# Altium schematic sheet LQV103SYSV2.SchDoc
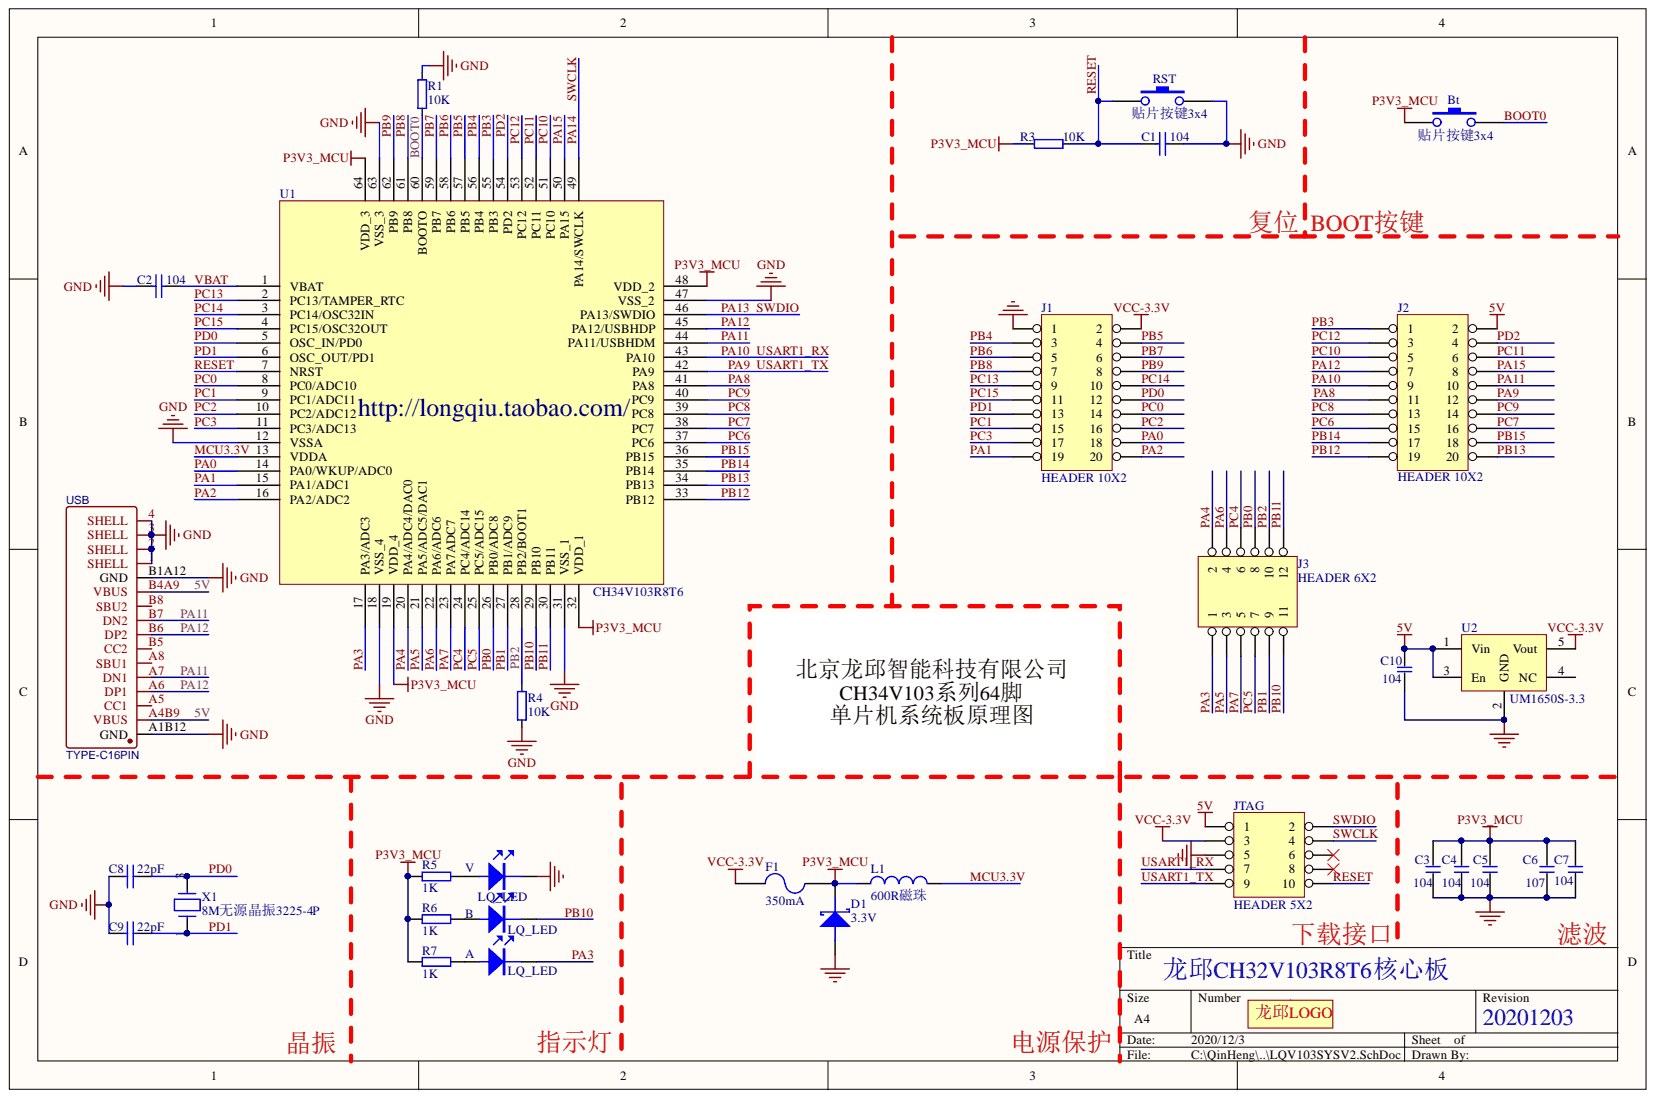
[diagram p0: LQV103SYSV2.SchDoc]

## Parts
A  LQ_LED  [LQ_0603D]
B  LQ_LED  [LQ_0603D]
Bt  贴片按键3x4  [LQ_BT2SMT3X4]
C1  104  [LQ_0603]
C2  104  [LQ_0603]
C3  104  [LQ_0603]
C4  104  [LQ_0603]
C5  104  [LQ_0603]
C6  107  [LQ_C1210T]
C7  104  [LQ_0603]
C8  22pF  [LQ_0603]
C9  22pF  [LQ_0603]
C10  104  [LQ_C0603]
D1  3.3V  [LQ_1206D]
F1  350mA  [LQ_1206]
J1  HEADER 10X2  [LQ_IDC10X2N]
J2  HEADER 10X2  [LQ_IDC10X2N]
J3  HEADER 6X2  [LQ_IDC6X2N]
JTAG  HEADER 5X2  [LQ_JTAG5X2SMT]
L1  600R磁珠  [LQ_0603]
LQ_GND  LQ_GND  [LQ_GND]  (value not drawn)
LQ_GND1  LQ_GND  [LQ_GND]  (value not drawn)
LQlogo1  LQlogo  [LOGO5X8MM]  (value not drawn)
R1  10K  [LQ_0603]
R3  10K  [LQ_0603]
R4  10K  [LQ_0603]
R5  1K  [LQ_0603]
R6  1K  [LQ_0603]
R7  1K  [LQ_0603]
RST  贴片按键3x4  [LQ_BT2SMT3X4]
U1  CH34V103R8T6  [LQFP-64_10x10x05P - STM32F107RCTx]
U2  UM1650S-3.3  [LQ_SOT-23-5]
USB  TYPE-C16PIN  [TYPE-C-SMD_TYPE-C-16PSMT]
V  LQ_LED  [LQ_0603D]
X1  8M无源晶振3225-4P  [LQ_XTAL3225]

## Nets
GND: C1.2 C2.1 C3.2 C4.2 C5.2 C6.2 C7.1 C8.1 C9.1 C10.2 D1.1 J1.1 JTAG.5 R1.2 R4.1 RST.2 U1.12(VSSA) U1.18(VSS_4) U1.31(VSS_1) U1.47(VSS_2) U1.63(VSS_3) U2.2(GND) USB.1(SHELL) USB.2(SHELL) USB.3(SHELL) USB.4(SHELL) USB.A1B12(GND) USB.B1A12(GND) V.2
P3V3_MCU: Bt.1 C3.1 C4.1 C5.1 C6.1 C7.2 D1.2 F1.2 L1.1 R3.1 R5.1 R6.1 R7.1 U1.19(VDD_4) U1.32(VDD_1) U1.48(VDD_2) U1.64(VDD_3)
VCC-3.3V: F1.1 J1.2 JTAG.3 U2.5(Vout)
5V: C10.1 J2.2 JTAG.1 U2.1(Vin) U2.3(En) USB.A4B9(VBUS) USB.B4A9(VBUS)
BOOT0: Bt.2 R1.1 U1.60(BOOTO)
MCU3.3V: L1.2 U1.13(VDDA)
PA0: J1.18 U1.14(PA0/WKUP/ADC0)
PA1: J1.19 U1.15(PA1/ADC1)
PA2: J1.20 U1.16(PA2/ADC2)
PA3: A.2 J3.1 U1.17(PA3/ADC3)
PA4: J3.2 U1.20(PA4/ADC4/DAC0)
PA5: J3.3 U1.21(PA5/ADC5/DAC1)
PA6: J3.4 U1.22(PA6/ADC6)
PA7: J3.5 U1.23(PA7ADC7)
PA8: J2.11 U1.41(PA8)
PA9: J2.12 JTAG.9 U1.42(PA9)
PA10: J2.9 JTAG.7 U1.43(PA10)
PA11: J2.10 U1.44(PA11/USBHDM) USB.A7(DN1) USB.B7(DN2)
PA12: J2.7 U1.45(PA12/USBHDP) USB.A6(DP1) USB.B6(DP2)
PA13: JTAG.2 U1.46(PA13/SWDIO)
PA14: JTAG.4 U1.49(PA14/SWCLK)
PA15: J2.8 U1.50(PA15)
PB0: J3.8 U1.26(PB0/ADC8)
PB1: J3.9 U1.27(PB1/ADC9)
PB2: J3.10 R4.2 U1.28(PB2/BOOT1)
PB3: J2.1 U1.55(PB3)
PB4: J1.3 U1.56(PB4)
PB5: J1.4 U1.57(PB5)
PB6: J1.5 U1.58(PB6)
PB7: J1.6 U1.59(PB7)
PB8: J1.7 U1.61(PB8)
PB9: J1.8 U1.62(PB9)
PB10: B.2 J3.11 U1.29(PB10)
PB11: J3.12 U1.30(PB11)
PB12: J2.19 U1.33(PB12)
PB13: J2.20 U1.34(PB13)
PB14: J2.17 U1.35(PB14)
PB15: J2.18 U1.36(PB15)
PC0: J1.14 U1.8(PC0/ADC10)
PC1: J1.15 U1.9(PC1/ADC11)
PC2: J1.16 U1.10(PC2/ADC12)
PC3: J1.17 U1.11(PC3/ADC13)
PC4: J3.6 U1.24(PC4/ADC14)
PC5: J3.7 U1.25(PC5/ADC15)
PC6: J2.15 U1.37(PC6)
PC7: J2.16 U1.38(PC7)
PC8: J2.13 U1.39(PC8)
PC9: J2.14 U1.40(PC9)
PC10: J2.5 U1.51(PC10)
PC11: J2.6 U1.52(PC11)
PC12: J2.3 U1.53(PC12)
PC13: J1.9 U1.2(PC13/TAMPER_RTC)
PC14: J1.10 U1.3(PC14/OSC32IN)
PC15: J1.11 U1.4(PC15/OSC32OUT)
PD0: C8.2 J1.12 U1.5(OSC_IN/PD0) X1.3
PD1: C9.2 J1.13 U1.6(OSC_OUT/PD1) X1.1
PD2: J2.4 U1.54(PD2)
RESET: C1.1 JTAG.10 R3.2 RST.1 U1.7(NRST)
VBAT: C2.2 U1.1(VBAT)
NetA_1: A.1 R7.2
NetB_1: B.1 R6.2
NetR5_2: R5.2 V.1

Not connected: JTAG 6 8; U2 4(NC); USB A5(CC1) A8(SBU1) B5(CC2) B8(SBU2)

## Library data of the parts
Bt RST = SW-PB: Component Kind: Standard
  RST: Library Name: chiusir.SchLib
C1 C2 C8 C9 = Cap: Capacitor; Component Kind: Standard; Library Name: 小车元器件库.SCHLIB
C3-C7 = LQ_CAP: CAP; Component Kind: Standard
C10 = LQ_CAP: Component Kind: Standard; Library Name: chiusir.SchLib
D1 = LQ_D: Schottky Diode; Component Kind: Standard
F1 = FUSE2: Fuse; Component Kind: Standard
L1 = 12*12*7: Component Kind: Standard; Library Name: 小车元器件库.SCHLIB
LQlogo1 = LQlogo: Connector; Component Kind: Standard; Library Name: chiusir.SchLib
R1 R3 R4 = RES: Component Kind: Standard; Library Name: 小车元器件库.SCHLIB
R5-R7 = LQ_RES: Component Kind: Standard; Description: 1K
U2 = MIC5205-3.3V: MIC5205-3.0V; Component Kind: Standard; Library Name: chiusir.SchLib
USB = TYPE-C16PIN: BOM_Manufacturer: SHOU HAN; Contributor: LCSC; BOM_Manufacturer Part: TYPE-C16PIN; BOM_Supplier: LCSC; BOM_Supplier Part: C393939; Component Kind: Standard; spicePre: U; spiceSymbolName: TYPE-C16PIN; Library Name: chiusir.SchLib
X1 = Crystal3225-4P: Component Kind: Standard; Description: Crystal3225-4P; Library Name: chiusir.SchLib
A B V = LQ_LED: Component Kind: Standard
J1 J2 = HEADER 10X2: Component Kind: Standard
J3 = HEADER 6X2: Component Kind: Standard
JTAG = HEADER 5X2: Component Kind: Standard
LQ_GND LQ_GND1 = LQ_GND: Component Kind: Standard; Library Name: chiusir.SchLib
U1 = CH34V103R8T6: Component Kind: Standard; Library Name: Schlib1.SchLib
